# Altium schematic sheet TEMP.SchDoc(TEMP)
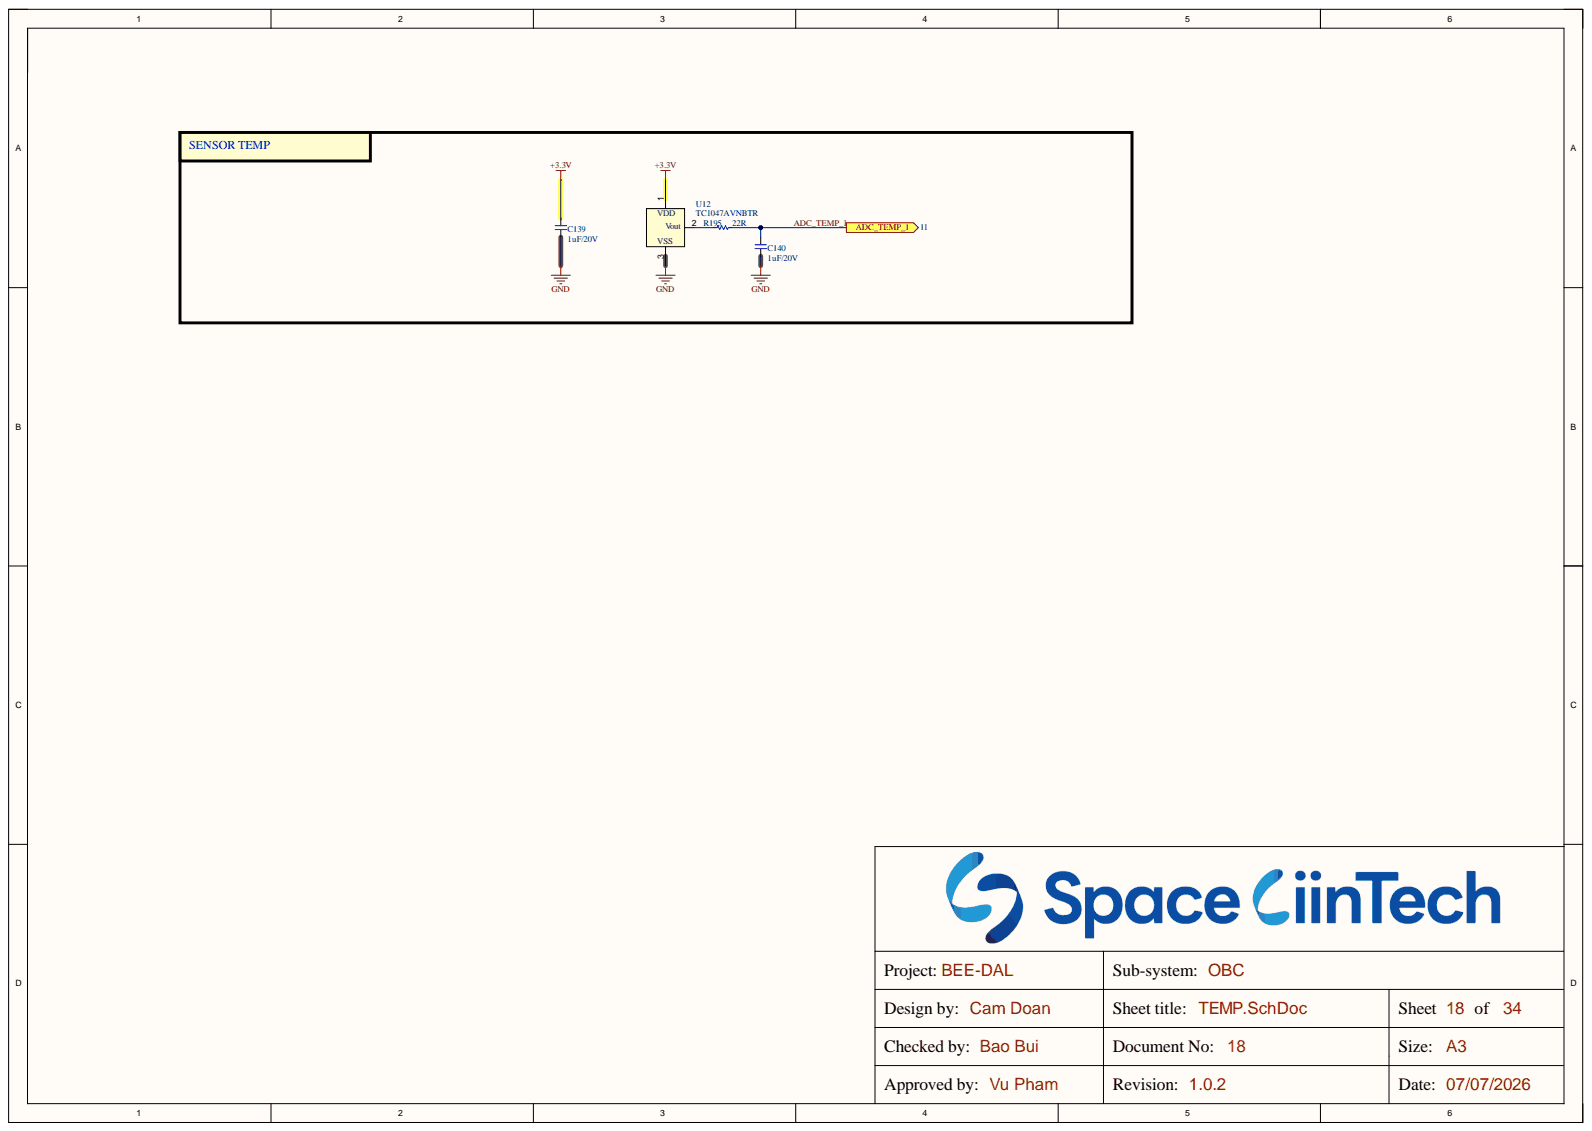
[diagram p0: TEMP.SchDoc]

## Parts
C139  1uF/20V  [CAP_C_1005X50_L]
C140  1uF/20V  [CAP_C_1005X50_L]
R195  22R  [RES_C_1005x30_L]
U12  TC1047AVNBTR  [SOT-23_MC_MCH]

## Ports
ADC_TEMP_1

## Nets
+3.3V: C139.2 U12.1
GND: C139.1 C140.1 U12.3
ADC_TEMP_1: C140.2 R195.2 ; port ADC_TEMP_1
NetR195_1: R195.1 U12.2

## Library data of the parts
C139 C140 = _CAP_C_2: CAP CHIP; Component Kind: Standard; Library Name: IFBschelib.SchLib
R195 = _RES_B_2: RES; Component Kind: Standard; Library Name: LABLIB.SchLib
U12 = TC1047AVNBTR: SENSOR ANALOG -40C-125C SOT23-3; Manufacturer_Name: Microchip; Component Kind: Standard; Manufacturer_Part_Number: TC1047AVNBTR; RefDes: RefDes; Type: Type; Copyright: Copyright (C) 2025 Ultra Librarian. All rights reserved.; Library Name: IFBschelib.SchLib
